# Altium schematic sheet PS__INN3947CQ_V2.SchDoc
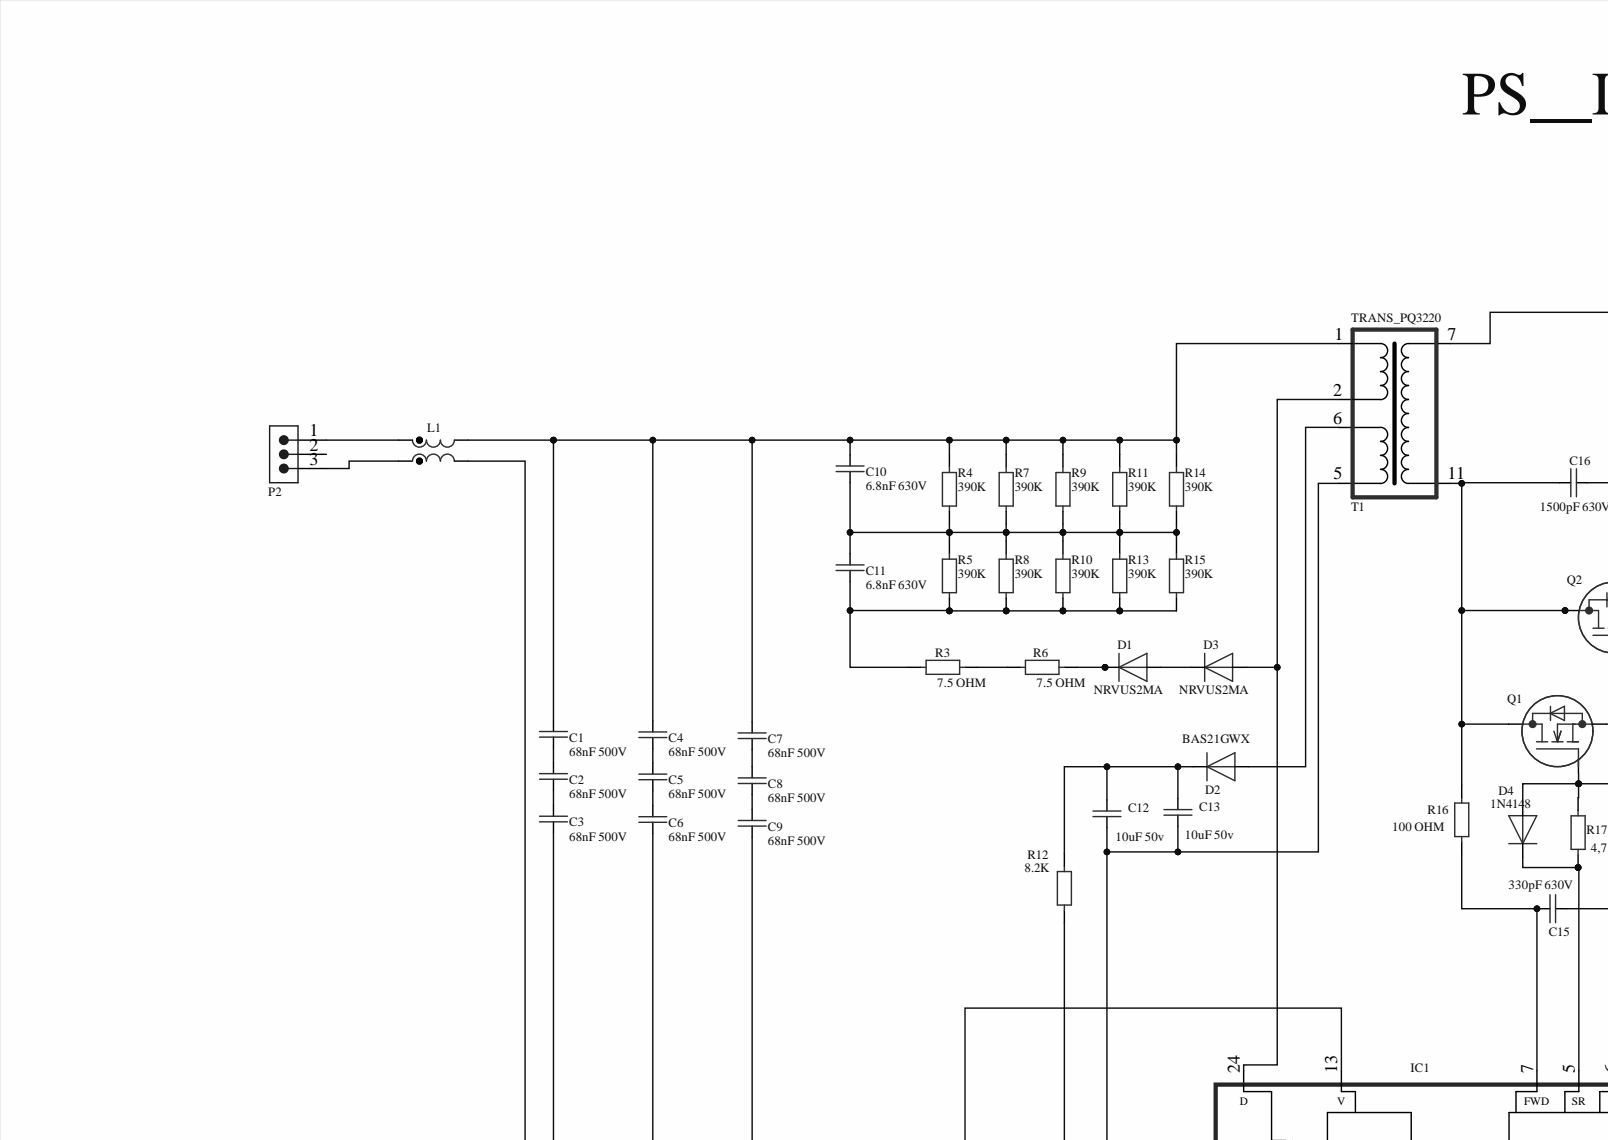
[diagram p0: PS__INN3947CQ_V2.SchDoc, top left]
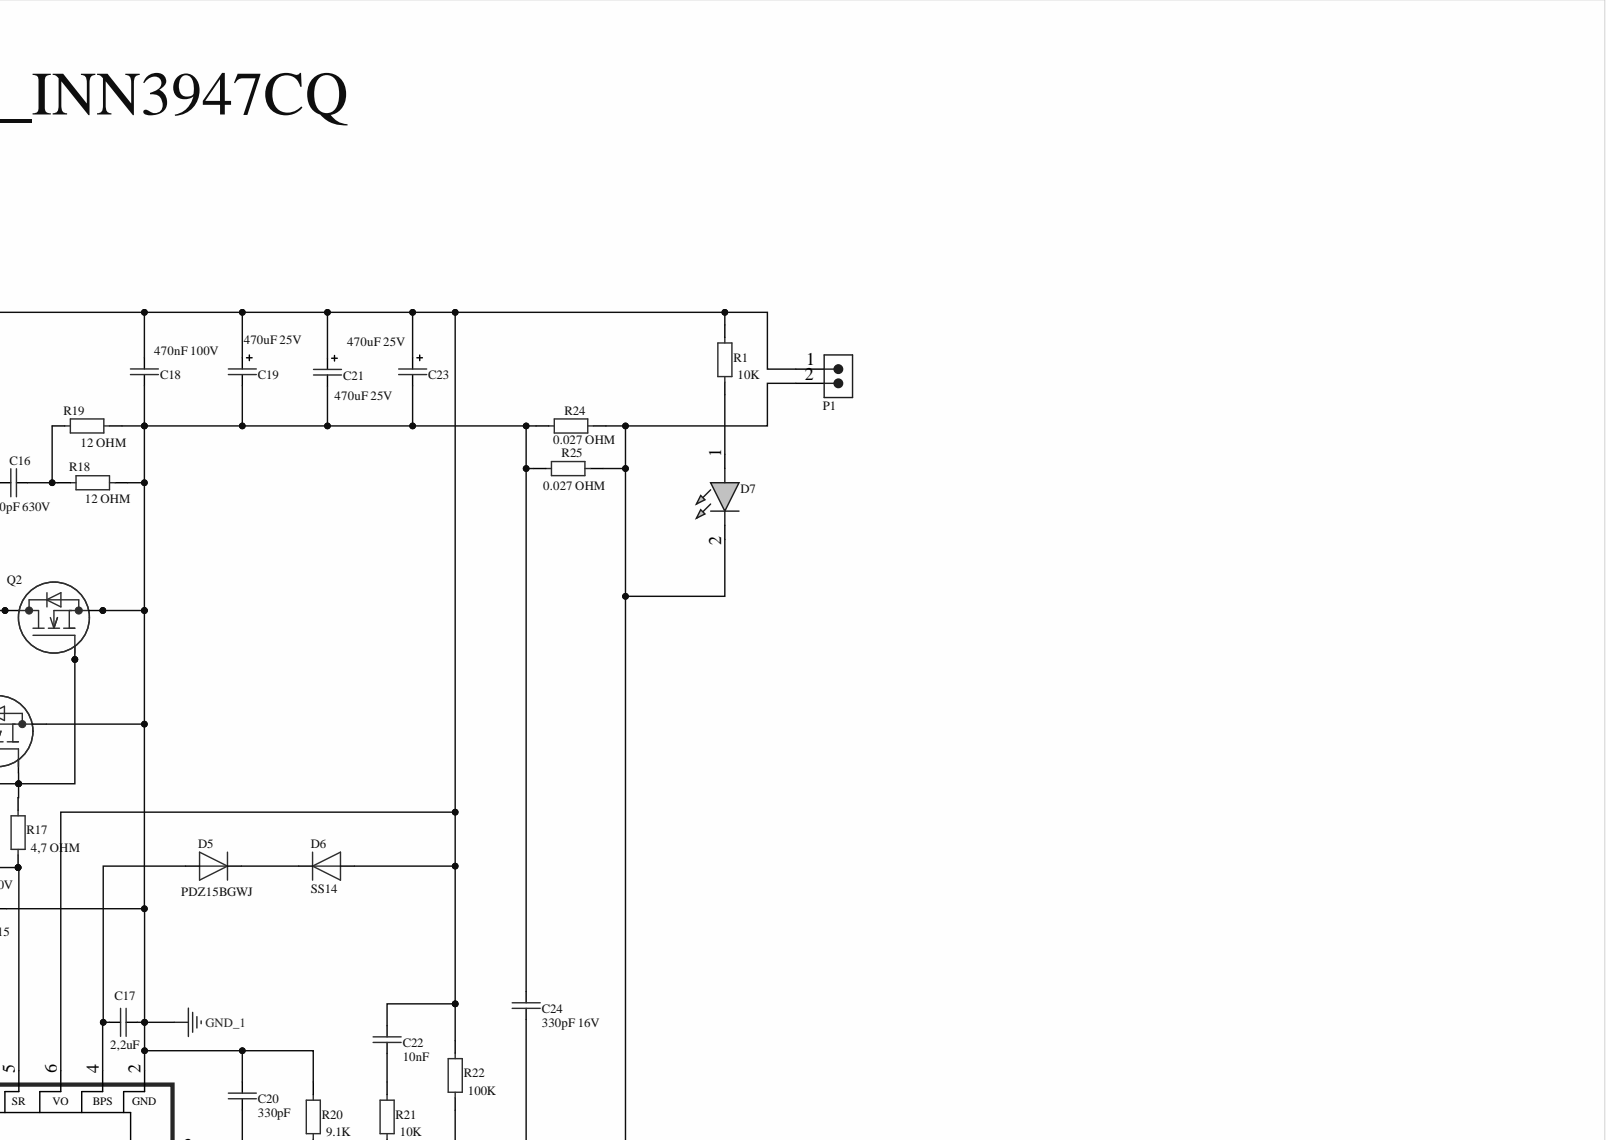
[diagram p1: PS__INN3947CQ_V2.SchDoc, top right]
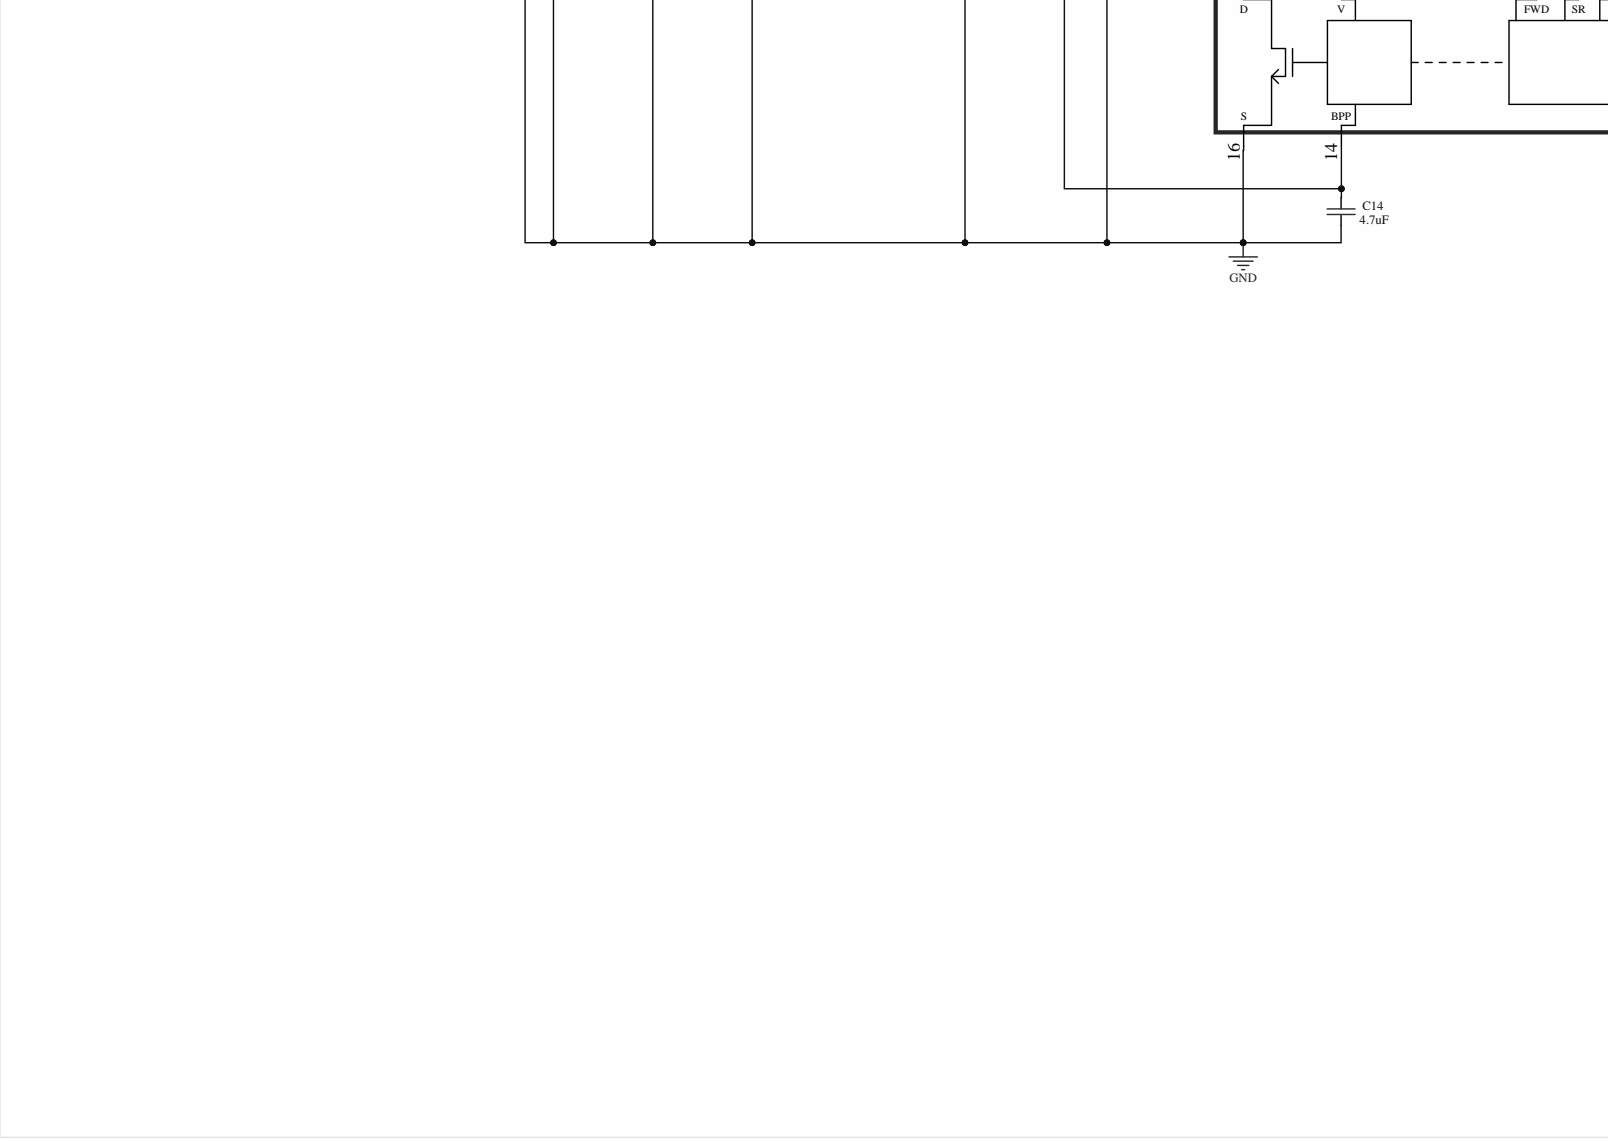
[diagram p2: PS__INN3947CQ_V2.SchDoc, bottom left]
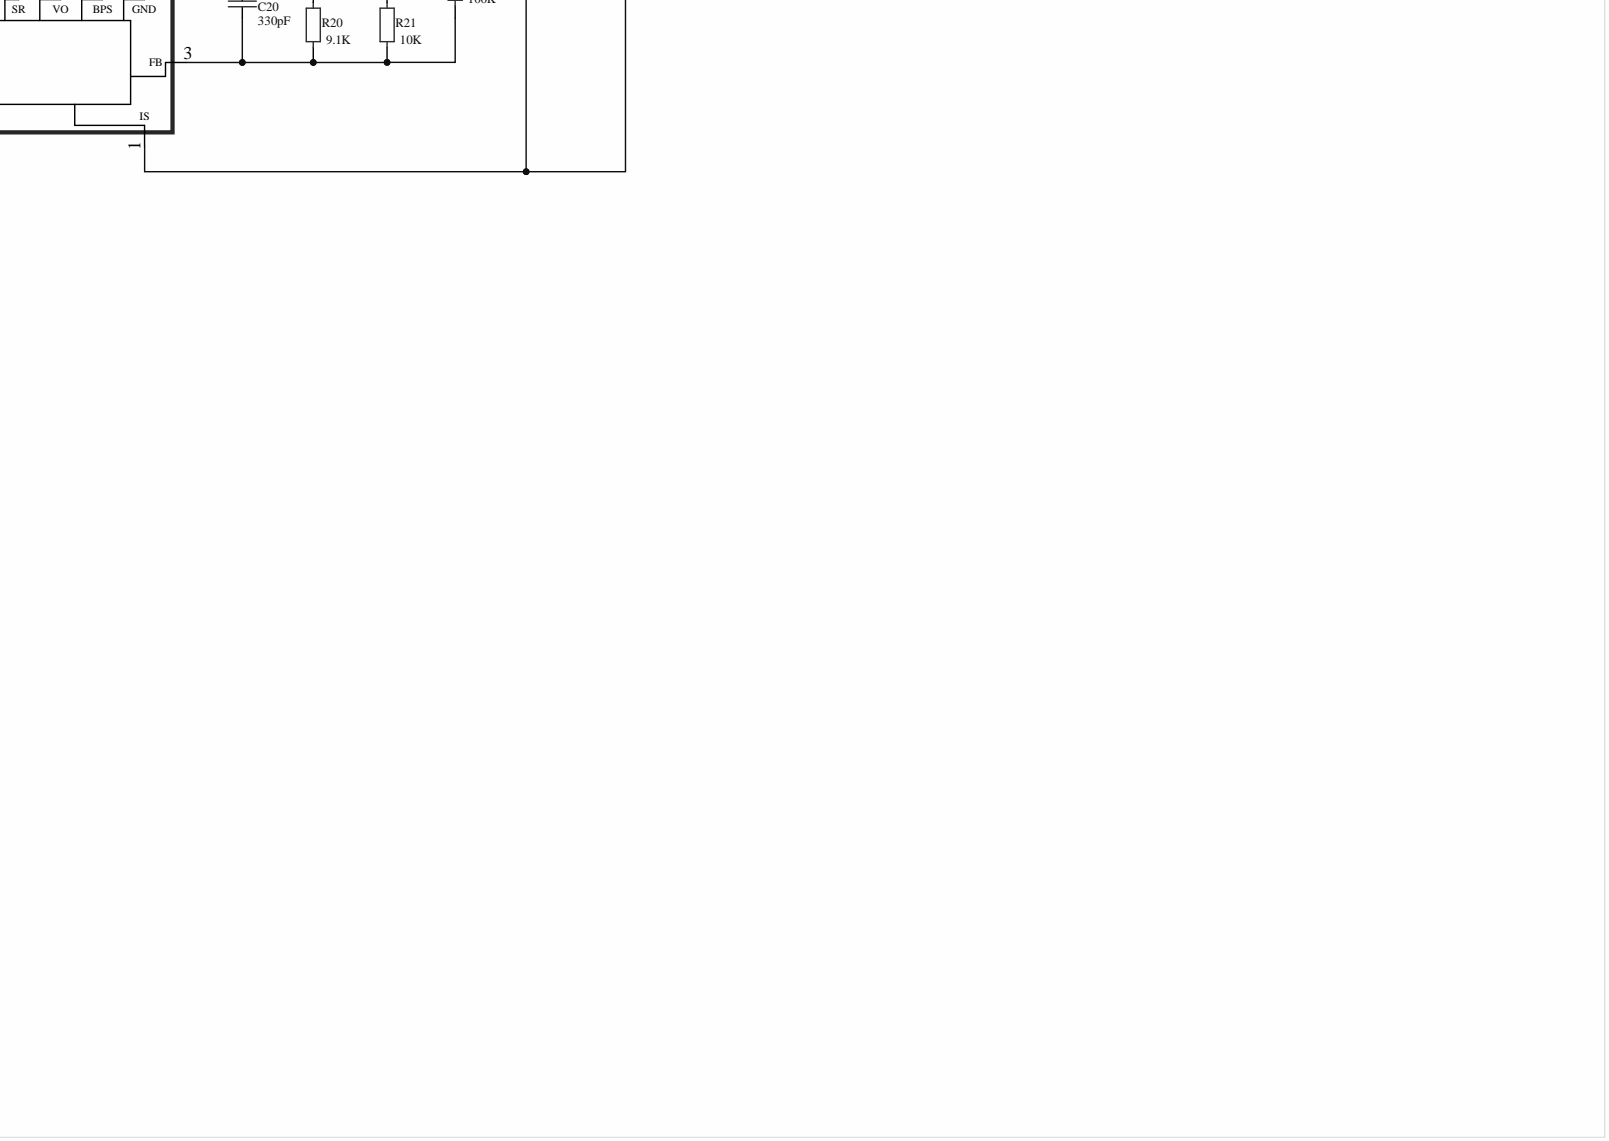
[diagram p3: PS__INN3947CQ_V2.SchDoc, bottom right]

## Parts
C1  68nF 500V  [CAP-1206]
C2  68nF 500V  [CAP-1206]
C3  68nF 500V  [CAP-1206]
C4  68nF 500V  [CAP-1206]
C5  68nF 500V  [CAP-1206]
C6  68nF 500V  [CAP-1206]
C7  68nF 500V  [CAP-1206]
C8  68nF 500V  [CAP-1206]
C9  68nF 500V  [CAP-1206]
C10  6.8nF 630V  [CAP-1206]
C11  6.8nF 630V  [CAP-1206]
C12  10uF 50v  [CAP-0805]
C13  10uF 50v  [CAP-0805]
C14  4.7uF  [CAP-0805]
C15  330pF 630V  [CAP-1206]
C16  1500pF 630V  [CAP-1206]
C17  2,2uF  [CAP-1206]
C18  470nF 100V  [CAP-0805]
C19  470uF 25V  [CAPPOL_SMD_SIZE_E]
C20  330pF  [CAP-0805]
C21  470uF 25V  [CAPPOL_SMD_SIZE_E]
C22  10nF  [CAP-0805]
C23  470uF 25V  [CAPPOL_SMD_SIZE_E]
C24  330pF 16V  [CAP-0805]
D1  NRVUS2MA  [SOD128]
D2  BAS21GWX  [SOD-123]
D3  NRVUS2MA  [DO-214AC, SMA]
D4  1N4148  [SOD-123]
D5  PDZ15BGWJ  [SOD-123]
D6  SS14  [DO-214AC, SMA]
D7  151031YS06000  [LED_3mm_RED]  (value not drawn)
IC1  INN3947CQ  [INN3947CQ]
L1  L_13x13mm  [L_13x13mm]  (value not drawn)
P1  691701530002B  [691701530002B]  (value not drawn)
P2  691701530003B  [691701530003B]  (value not drawn)
Q1  DMT15H017LPS  [DMT15H017LPS_SWP]  (value not drawn)
Q2  DMT15H017LPS  [DMT15H017LPS_SWP]  (value not drawn)
R1  10K  [RES-0805]
R3  7.5 OHM  [RES-2512]
R4  390K  [RES-1206]
R5  390K  [RES-1206]
R6  7.5 OHM  [RES-2512]
R7  390K  [RES-1206]
R8  390K  [RES-1206]
R9  390K  [RES-1206]
R10  390K  [RES-1206]
R11  390K  [RES-1206]
R12  8.2K  [RES-0805]
R13  390K  [RES-1206]
R14  390K  [RES-1206]
R15  390K  [RES-1206]
R16  100 OHM  [RES-0805]
R17  4,7 OHM  [RES-0805]
R18  12 OHM  [RES-0805]
R19  12 OHM  [RES-0805]
R20  9.1K  [RES-0805]
R21  10K  [RES-0805]
R22  100K  [RES-0805]
R24  0.027 OHM  [RES-1206]
R25  0.027 OHM  [RES-1206]
T1  TRANS_PQ3220  [TRANS_PQ3220]

## Nets
GND: C3.2 C6.2 C9.2 C12.1 C13.1 C14.1 IC1.13(V) IC1.16(S) L1.4 T1.5
GND_1: C15.2 C17.2 C18.1 C19.2 C20.2 C21.2 C23.2 C24.2 IC1.2 Q1.3 Q2.3 R18.1 R19.1 R20.2 R24.2 R25.2
NetC1_1: C1.1 C4.1 C7.1 C10.1 L1.2 R4.1 R7.1 R9.1 R11.1 R14.1 T1.1
NetC1_2: C1.2 C2.1
NetC2_2: C2.2 C3.1
NetC4_2: C4.2 C5.1
NetC5_2: C5.2 C6.1
NetC7_2: C7.2 C8.1
NetC8_2: C8.2 C9.1
NetC10_2: C10.2 C11.1 R4.2 R5.1 R7.2 R8.1 R9.2 R10.1 R11.2 R13.1 R14.2 R15.1
NetC11_2: C11.2 R3.2 R5.2 R8.2 R10.2 R13.2 R15.2
NetC12_2: C12.2 C13.2 D2.1 R12.2
NetC14_2: C14.2 IC1.14 R12.1
NetC15_1: C15.1 IC1.7 R16.1
NetC16_1: C16.1 R18.2 R19.2
NetC16_2: C16.2 Q1.2 Q2.2 R16.2 T1.11
NetC17_1: C17.1 D5.2 IC1.4
NetC18_2: C18.2 C19.1 C21.1 C22.2 C23.1 D6.2 IC1.6(VO) P1.1 R1.2 R22.2 T1.7
NetC20_1: C20.1 IC1.3(FB) R20.1 R21.1 R22.1
NetC22_1: C22.1 R21.2
NetC24_1: C24.1 D7.2 IC1.1(IS) P1.2 R24.1 R25.1
NetD1_1: D1.1 R6.1
NetD1_2: D1.2 D3.1
NetD2_2: D2.2 T1.6
NetD3_2: D3.2 IC1.24(D) T1.2
NetD4_1: D4.1 IC1.5(SR) R17.1
NetD4_2: D4.2 Q1.1 Q2.1 R17.2
NetD5_1: D5.1 D6.1
NetD7_1: D7.1 R1.1
NetL1_1: L1.1 P2.1
NetL1_3: L1.3 P2.3
NetR3_1: R3.1 R6.2

Not connected: P2 2

## Library data of the parts
C1-C18 C20 C22 C24 = CAP-NON-POLAR: Component Kind: Standard; Library Name: PAS_COMPONENTS.IntLib
  C1-C9: Description: 68nF 500V
  C10 C11: Description: 6.8nF 630V
  C12 C13: Description: 10uF 50v
  C14: Description: 4.7uF
  C15: Description: 330pF 630V
  C16: Description: 1500pF 630V
  C17: Description: 2,2uF
  C18: Description: 470nF 100V
  C20: Description: 330pF
  C22: Description: 10nF
  C24: Description: 330pF 16V
C19 C21 C23 = CAP-POLAR: Component Kind: Standard; Description: 470uF 25V; Library Name: PAS_COMPONENTS.IntLib
D1-D6 = DIODE: Component Kind: Standard; Library Name: DIODES.IntLib
  D1 D3: Description: NRVUS2MA
  D2: Description: BAS21GWX
  D4: Description: 1N4148
  D5: Description: PDZ15BGWJ
  D6: Description: SS14
D7 = LED: WURTH ELEKTRONIK - 151031YS06000 - LED, Yellow, Through Hole, T-1 (3mm), 30 mA, 2 V, 590 nm; Geometry.Height: 5.5mm; Component Kind: Standard; Datasheet Link: https://katalog.we-online.de/led/datasheet/151031YS06000.pdf; Manufacturer_Name: Wurth Elektronik; Manufacturer_Part_Number: 151031YS06000; Mouser Part Number: 710-151031YS06000; Mouser Price/Stock: https://www.mouser.co.uk/ProductDetail/Wurth-Elektronik/151031YS06000?qs=LlUlMxKIyB3xSYYW%252BcuBiQ%3D%3D; Library Name: Optic-LED.IntLib
P1 = 691701530002B: WR-TBL Series 7015B Open Horizontal PCB Header, SMT, pitch 5.08mm, 2p; Manufacturer: Wurth Elektronik; Modularity: No; Rated Current: 10A; Working Voltage: 300V; Application: Pluggable; Category: Terminal Blocks; Component Kind: Standard; ComponentLink1Description: Website Link; ComponentLink1URL: https://www.we-online.com/redexpert/article/691701530002B?ad; ComponentLink2Description: Datasheet Link; ComponentLink2URL: https://www.we-online.com/redexpert/spec/691701530002B?ad; Library Name: Altium_WR-TBL (rev23f).IntLib; Manufacturer Part Number: 691701530002B; Match Code: WR-TBL; Mount: Surface Mount; Number of Pins: 2; Number of Rows: 1; Operating Temperature Max: 105°C; Operating Temperature Min: -40°C; Orientation: Horizontal; Packaging: Box; Pitch: 5.08mm
P2 = 691701530003B: WR-TBL Series 7015B Open Horizontal PCB Header, SMT, pitch 5.08mm, 3p; Manufacturer: Wurth Elektronik; Modularity: No; Rated Current: 10A; Working Voltage: 300V; Application: Pluggable; Category: Terminal Blocks; Component Kind: Standard; ComponentLink1Description: Website Link; ComponentLink1URL: https://www.we-online.com/redexpert/article/691701530003B?ad; ComponentLink2Description: Datasheet Link; ComponentLink2URL: https://www.we-online.com/redexpert/spec/691701530003B?ad; Library Name: Altium_WR-TBL (rev23f).IntLib; Manufacturer Part Number: 691701530003B; Match Code: WR-TBL; Mount: Surface Mount; Number of Pins: 3; Number of Rows: 1; Operating Temperature Max: 105°C; Operating Temperature Min: -40°C; Orientation: Horizontal; Packaging: Box; Pitch: 5.08mm
R1 R3-R22 R24 R25 = RES-CONST: Component Kind: Standard; Library Name: PAS_COMPONENTS.IntLib
  R1 R21: Description: 10K
  R3 R6: Description: 7.5 OHM
  R4 R5 R7-R11 R13-R15: Description: 390K
  R12: Description: 8.2K
  R16: Description: 100 OHM
  R17: Description: 4,7 OHM
  R18 R19: Description: 12 OHM
  R20: Description: 9.1K
  R22: Description: 100K
  R24 R25: Description: 0.027 OHM
IC1 = INN3947CQ: Component Kind: Standard; Description: INN3947CQ; Library Name: INN3947CQ.IntLib
L1 = L_13x13mm: Component Kind: Standard; Description: L_13x13mm; Library Name: L_13x13mm.IntLib
Q1 Q2 = DMT15H017LPS: Component Kind: Standard; Description: DMT15H017LPS; Library Name: DMT15H017LPS.IntLib
T1 = TRANS_PQ3220: Component Kind: Standard; Description: TRANS_PQ3220; Library Name: TRANS_PQ3220.IntLib
